# Altium schematic sheet 2022_AESK_UAV_Sensors.SchDoc(2022_AESK_UAV_Sensors)
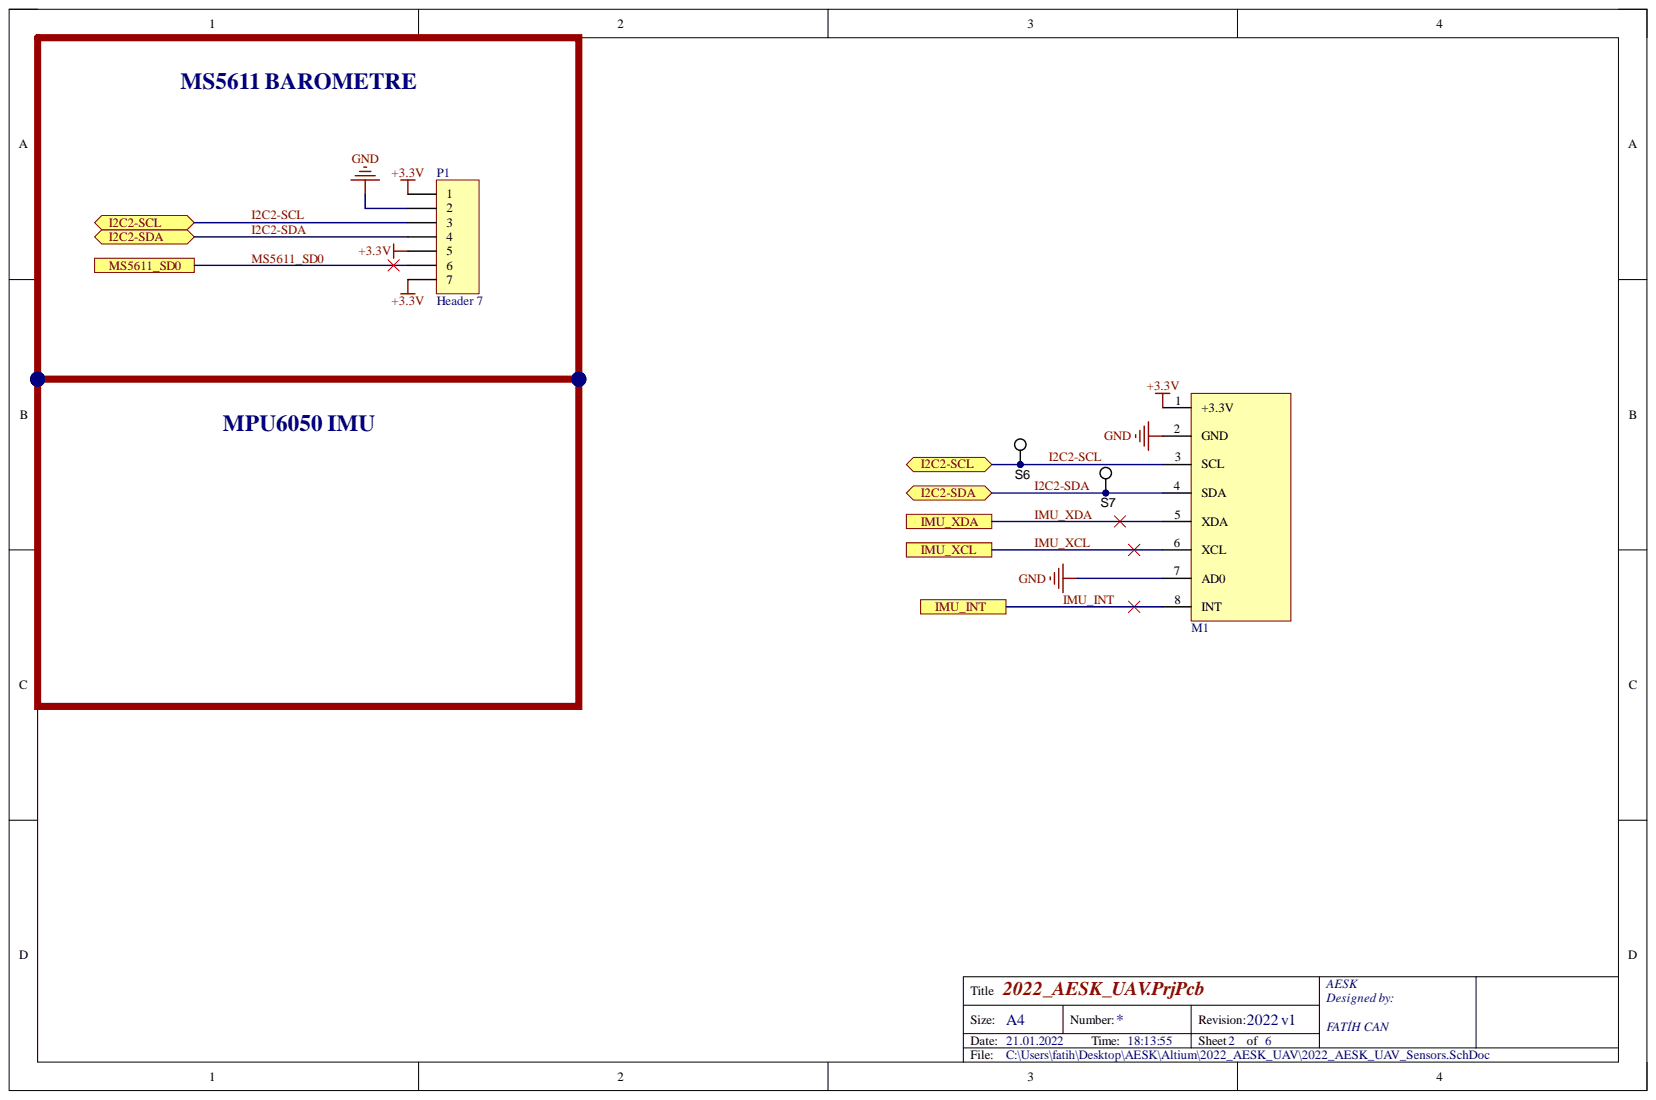
[diagram p0: 2022_AESK_UAV_Sensors.SchDoc]

## Parts
M1  MPU-9255(resim ve supplier bilgileri mpu6050dir)  [MPU-9255(GY-87)]  (value not drawn)
P1  Header 7  [HDR1X7]
S6  5002  [Keystone5002]  (value not drawn)
S7  5002  [Keystone5002]  (value not drawn)

## Ports
I2C2-SCL, I2C2-SDA, IMU_INT, IMU_XCL, IMU_XDA, MS5611_SD0

## Nets
+3.3V: M1.1(+3.3V) P1.1 P1.5 P1.7
GND: M1.2(GND) M1.7(AD0) P1.2
I2C2-SCL: M1.3(SCL) P1.3 S6.1 ; port I2C2-SCL
I2C2-SDA: M1.4(SDA) P1.4 S7.1 ; port I2C2-SDA
IMU_INT: M1.8(INT) ; port IMU_INT
IMU_XCL: M1.6(XCL) ; port IMU_XCL
IMU_XDA: M1.5(XDA) ; port IMU_XDA
MS5611_SD0: P1.6 ; port MS5611_SD0

## Library data of the parts
M1 = MPU-9255(GY-87): Manufacturer 1: TDK InvenSense; Manufacturer Part Number 1: MPU-6050EVB; Evaluation Kit: Yes; Sensor Type: Accelerometer,Gyroscope,3 Axis; Interface: I2C; category: Sensor; Component Kind: Standard; ComponentLink1Description: MPU-9255(gyroscope/accellemeter/magnometer/barometer); ComponentLink1URL: https://pdf.direnc.net/upload/mpu-9255-datasheet.pdf; ComponentLink2Description: https://store.invensense.com/datasheets/invensense/MPU-6000EVB.pdf?src-supplier=Digi-Key; ComponentLink2URL: https://store.invensense.com/datasheets/invensense/MPU-6000EVB.pdf?src-supplier=Digi-Key; Package Quantity: 1; Pricing 1: 1=56,00000, 5=50,75000, 10=48,12500, 25=46,55000 (USD); RoHS: Yes; Stock 1: 2; Supplier 1: Digi-Key; Supplier Part Number 1: 1428-1008-ND; Library Name: 2022_AESK_UAV.SchLib
P1 = Header 7: Header, 7-Pin; Component Kind: Standard; LatestRevisionDate: 17-Jul-2002; LatestRevisionNote: Re-released for DXP Platform.; Library Name: Miscellaneous Connectors.IntLib; Signal Integrity: Connector; Publisher: Altium Limited
S6 S7 = 5002: Test Point, TH, Miniature, White; Manufacturer: Keystone; CompType: Test Point Loop; U/M: EA; Alternate Manufacturer: -; Alternate PartNumber: -; Component Kind: Standard; ComponentLink1Description: Datasheet Link; ComponentLink1URL: http://www.keyelco.com/pdfs/M55p51.pdf; DigiKeyPartNumber: 5002K-ND; LatestRevisionDate: 2011-09-29; LatestRevisionNote: Removed DigiKeyPartNumber parameter.; MountType: TH; PackageReference: Keystone5002; PartNumber: 5002; RoHS: Y; Supplier 1: Digi-Key; Supplier 2: Newark; Supplier Part Number 1: 5002K-ND; Supplier Part Number 2: 82H9632; SymbolVersion: 2008-04-04; Value: White; Library Name: 2022_AESK_UAV.SchLib; Publisher: National Semiconductor
